# Altium schematic sheet [1]_STLU01.SchDoc([1]_STLU01)
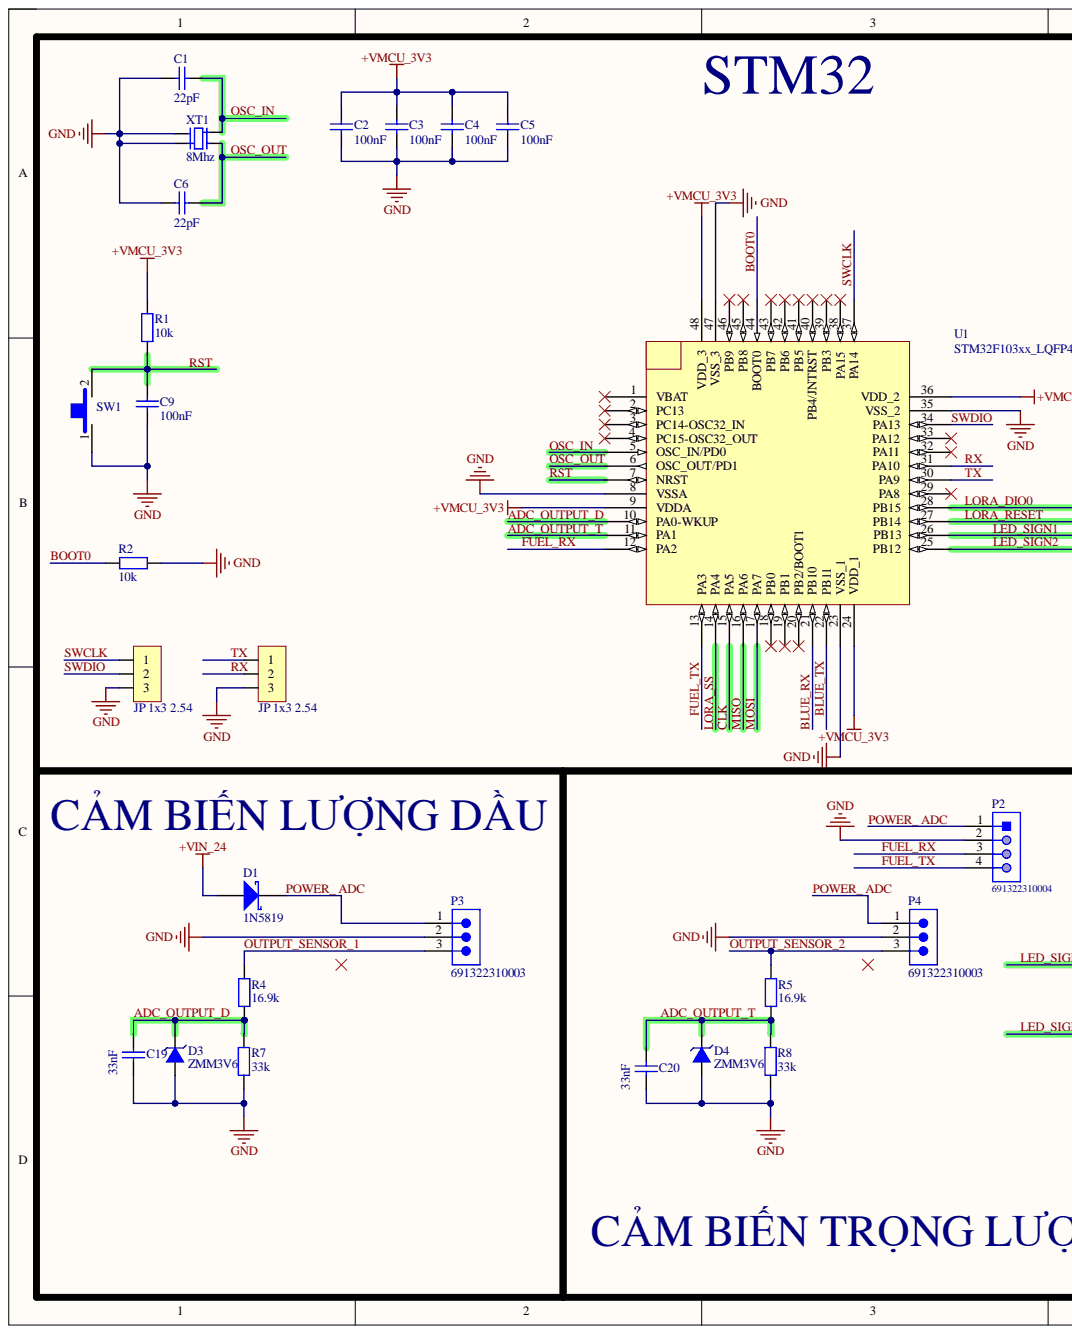
[diagram p0: [1]_STLU01.SchDoc, left]
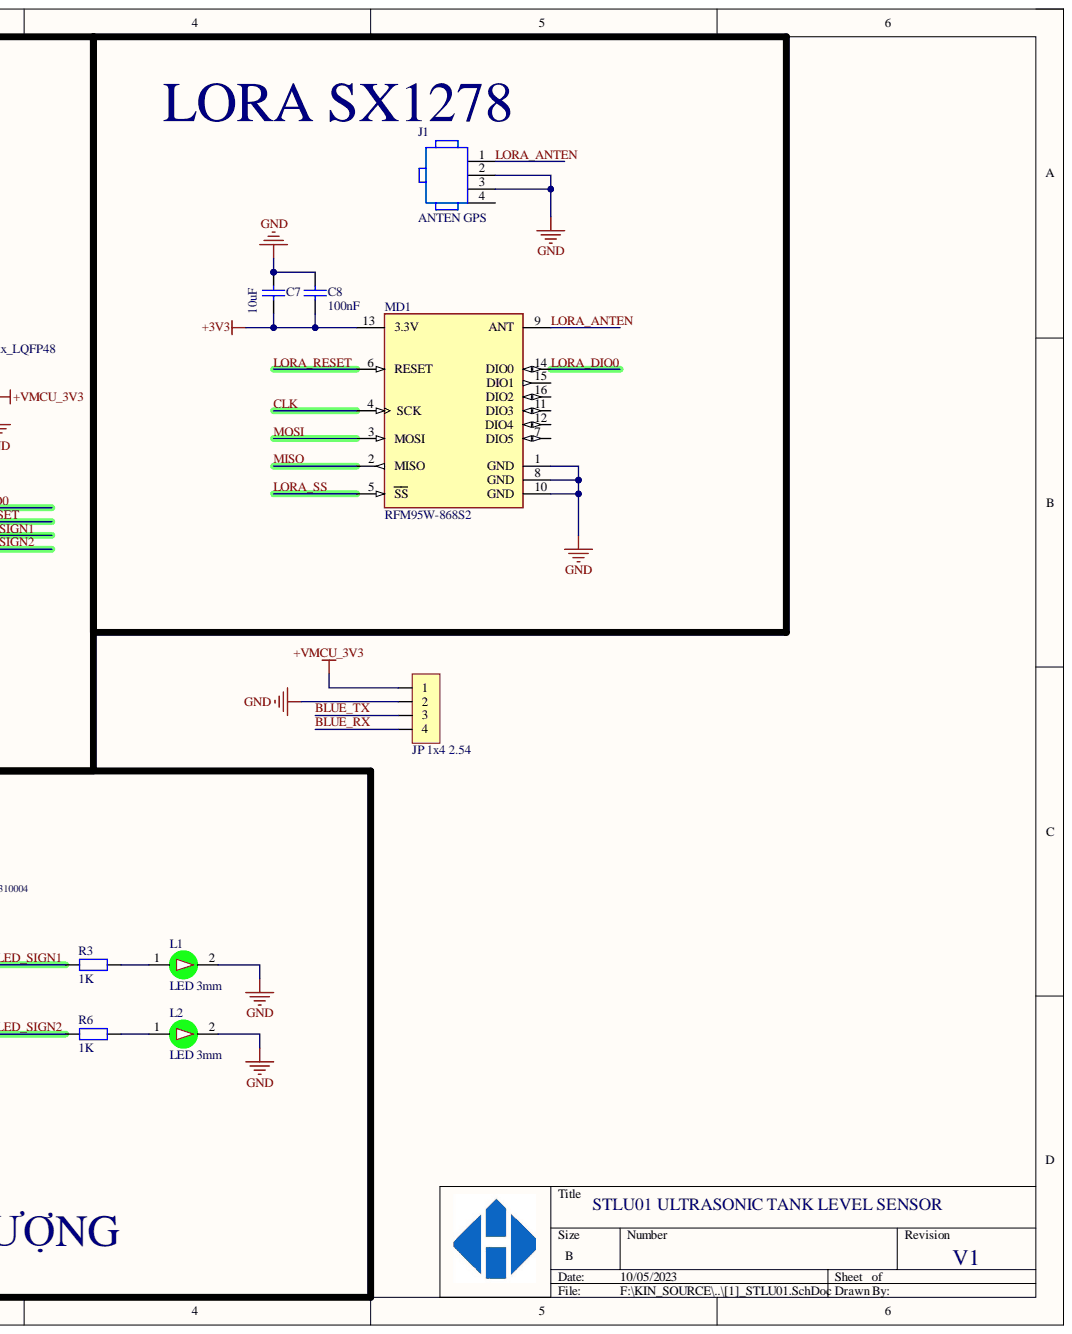
[diagram p1: [1]_STLU01.SchDoc, right]

## Parts
C1  22pF  [tu 0603]
C2  100nF  [tu 0603]
C3  100nF  [tu 0603]
C4  100nF  [tu 0603]
C5  100nF  [tu 0603]
C6  22pF  [tu 0603]
C7  10uF  [tu 0603]
C8  100nF  [tu 0603]
C9  100nF  [tu 0603]
C19  33nF  [tu 0603]
C20  33nF  [tu 0603]
D1  1N5819  [DO-214AA]
D3  ZMM3V6  [SOD_80]
D4  ZMM3V6  [SOD_80]
J1  ANTEN GPS  [TG-RECE-20369-001E-4_V]
JP1  JP 1x3 2.54  [JP 1x3 DT]
JP2  JP 1x3 2.54  [JP 1x3 DT]
JP3  JP 1x4 2.54  [JP 1x4 DT]
L1  LED 3mm  [LED-3MM-GREEN]
L2  LED 3mm  [LED-3MM-GREEN]
MD1  RFM95W-868S2  [FP-RFM95W-868S2-MFG]
P2  691322310004  [691322310004]
P3  691322310003  [691322310003]
P4  691322310003  [691322310003]
R1  10k  [tro smd 0603]
R2  10k  [tro smd 0603]
R3  1K  [tro smd 0805]
R4  16.9k  [tro smd 0805]
R5  16.9k  [tro smd 0805]
R6  1K  [tro smd 0805]
R7  33k  [tro smd 0805]
R8  33k  [tro smd 0805]
SW1  Tactile  [SW 2P SMD]  (value not drawn)
U1  STM32F103xx_LQFP48  [STM32_LQPF48]
XT1  8Mhz  [Thach Anh 4 chan 1]

## Nets
+3V3: C7.2 C8.2 MD1.13(3.3V)
+VIN_24: D1.1
+VMCU_3V3: C2.1 C3.1 C4.1 C5.1 JP3.1 R1.2 U1.9(VDDA) U1.24(VDD_1) U1.36(VDD_2) U1.48(VDD_3)
GND: C1.2 C2.2 C3.2 C4.2 C5.2 C6.2 C7.1 C8.1 C9.2 C19.2 C20.2 D3.1 D4.1 J1.2 J1.3 JP1.3 JP2.3 JP3.2 L1.2 L2.2 MD1.1(GND) MD1.8(GND) MD1.10(GND) P2.2 P3.2 P4.2 R2.1 R7.1 R8.1 SW1.1 U1.8(VSSA) U1.23(VSS_1) U1.35(VSS_2) U1.47(VSS_3) XT1.2 XT1.4
ADC_OUTPUT_D: C19.1 D3.2 R4.1 R7.2 U1.10(PA0-WKUP)
ADC_OUTPUT_T: C20.1 D4.2 R5.1 R8.2 U1.11(PA1)
BLUE_RX: JP3.4 U1.21(PB10)
BLUE_TX: JP3.3 U1.22(PB11)
BOOT0: R2.2 U1.44(BOOT0)
CLK: MD1.4(SCK) U1.15(PA5)
FUEL_RX: P2.3 U1.12(PA2)
FUEL_TX: P2.4 U1.13(PA3)
LED_SIGN1: R3.2 U1.26(PB13)
LED_SIGN2: R6.2 U1.25(PB12)
LORA_ANTEN: J1.1 MD1.9(ANT)
LORA_DIO0: MD1.14(DIO0) U1.28(PB15)
LORA_RESET: MD1.6(RESET) U1.27(PB14)
LORA_SS: MD1.5(SS) U1.14(PA4)
MISO: MD1.2(MISO) U1.16(PA6)
MOSI: MD1.3(MOSI) U1.17(PA7)
OSC_IN: C1.1 U1.5(OSC_IN/PD0) XT1.3
OSC_OUT: C6.1 U1.6(OSC_OUT/PD1) XT1.1
OUTPUT_SENSOR_1: P3.3 R4.2
OUTPUT_SENSOR_2: P4.3 R5.2
POWER_ ADC: D1.2 P2.1 P3.1 P4.1
RST: C9.1 R1.1 SW1.2 U1.7(NRST)
RX: JP2.2 U1.31(PA10)
SWCLK: JP1.1 U1.37(PA14)
SWDIO: JP1.2 U1.34(PA13)
TX: JP2.1 U1.30(PA9)
NetL1_1: L1.1 R3.1
NetL2_1: L2.1 R6.1

Not connected: J1 4; MD1 7(DIO5) 11(DIO3) 12(DIO4) 15(DIO1) 16(DIO2); U1 1(VBAT) 2(PC13) 3(PC14-OSC32_IN) 4(PC15-OSC32_OUT) 18(PB0) 19(PB1) 20(PB2/BOOT1) 29(PA8) 32(PA11) 33(PA12) 38(PA15) 39(PB3) 40(PB4/JNTRST) 41(PB5) 42(PB6) 43(PB7) 45(PB8) 46(PB9)

## Library data of the parts
C1 C6 = Tu 0603 101 50V 10%: Dán; Don_Vi: Con; Ma_Hang: MH004565; So_Chan: 2; Ten_Linh_Kien: Tụ 0603 101-0.1nF 10% 50V; Chung_Loai: Dán; Component Kind: Standard; Library Name: Altium_Lib_by_KhueNguyenCreator.IntLib
C2-C5 C8 = Tu 0603 104 50V 10%: Dán; Don_Vi: Con; Ma_Hang: MH004568; So_Chan: 2; Ten_Linh_Kien: Tụ 0603 104-100nF 10% 50V; Chung_Loai: Dán; Component Kind: Standard; Library Name: Altium_Lib_by_KhueNguyenCreator.IntLib
C7 C19 C20 = Tu 0603 105 16V 20%: Dán; Don_Vi: Con; Ma_Hang: MH004574; So_Chan: 2; Ten_Linh_Kien: Tụ 0603 105-1uF 20% 16V; Chung_Loai: Dán; Component Kind: Standard; Library Name: Altium_Lib_by_KhueNguyenCreator.IntLib
C9 = Tu 0603 105 50V 10%: Dán; Don_Vi: Con; Ma_Hang: MH004569; So_Chan: 2; Ten_Linh_Kien: Tụ 0603 105-1uF 50V 10%; Chung_Loai: Dán; Component Kind: Standard; Library Name: Altium_Lib_by_KhueNguyenCreator.IntLib
D1 = DIO_SS14: DIODE SCHOTTKY 1A 40V SMA DO-214AA; Manufacturer: Fairchild; Part number: SS14; Component Kind: Standard; ComponentLink1Description: Link product; ComponentLink1URL: https://www.thegioiic.com/ss14-sma-diode-schottky-1a-1n5819; Cost: 600; Package: DO-214AA; Library Name: COMPONENT_INS.IntLib
D3 D4 = DIO_ZMM3V6: ZMM3V6 3.6V 500mW SMD SOD-80; Manufacturer: On Semiconductor; Component Kind: Standard; ComponentLink1Description: Link product; ComponentLink1URL: https://www.thegioiic.com/zmm3v6-diode-zener-3-6v-500mw-smd; Cost: 250; Package: SOD-80; Part number: ZMM3V6; Library Name: COMPONENT_INS.IntLib
J1 = CMP-0275-00001-2: RF Connector, Onboard Receptacle, IPEX MHFIII; Manufacturer: Taoglas; PartNumber: RECE-20369-001E-01; Packing: Tape and Reel; Component Kind: Standard; ComponentLink1Description: Manufacturer URL; ComponentLink1URL: http://www.taoglas.com/; ComponentLink2Description: Datasheet; ComponentLink2URL: http://www.taoglas.com/images/product_images/original_images/RECE.20369.001E.01.pdf; ComponentLink3Description: Package Specification; ComponentLink3URL: http://www.taoglas.com/images/product_images/original_images/RECE.20369.001E.01.pdf; DatasheetVersion: Rev. C; Housing Color: Gold; Library Name: Altium Content Vault; Mounting Technology: Surface Mount; PackageDescription: 4-Pin, Hand Drawn, Body 1.7x1.7mm; PackageReference: RECE-20369-001E-01-4; PackageVersion: Rev. C
JP1 JP2 = JP 1x3 2.54 Duc Thang: Cắm; Don_Vi: Con; Ma_Hang: MH000903; Ten_Linh_Kien: Jump Đơn Đực 2.54MM 1x40P Thẳng 11MM; Chung_Loai: Cắm; Component Kind: Standard; Library Name: Altium_Lib_by_KhueNguyenCreator.IntLib
JP3 = JP 1x4 2.54 Duc Thang: Cắm; Don_Vi: Con; Ma_Hang: MH000903; Ten_Linh_Kien: Jump Đơn Đực 2.54MM 1x40P Thẳng 11MM; Chung_Loai: Cắm; Component Kind: Standard; Library Name: Altium_Lib_by_KhueNguyenCreator.IntLib
L1 L2 = LED 3mm Xanh La: Cắm; Don_Vi: Con; Ma_Hang: MH001054; Ten_Linh_Kien: Led 3MM Phủ Xanh (10c); Chung_Loai: Cắm; Component Kind: Standard; Library Name: Altium_Lib_by_KhueNguyenCreator.IntLib
MD1 = CMP-204316-000001-1: RX TXRX MODULE ISM < 1GHZ SMD; Current - Receiving: 12.1mA; Current - Transmitting: 120mA; Data Rate: 300Kbps; Frequency: 868MHz; Interface: SPI; Sensitivity: -123dBm; Case/Package: SMD/SMT; Component Kind: Standard; Library Name: Altium Content Vault; Max Operating Temperature: 70°C; Min Operating Temperature: -20°C; Mount: Surface Mount; Packaging: Bulk
P2 = CMP-1502-01888-1: Serie 322 - 3.81mm Horizontal PCB Header WR-TBL, 4 pin; Manufacturer: Wurth Elektronik; Gender: Male; Pin Number: 4; Component Kind: Standard; Datasheet URL: http://katalog.we-online.de/em/datasheet/6913223100xx.pdf; Library Name: Altium Content Vault; Manufacturer URL: http://www.we-online.de; Mounting Technology: THT; Operating Temperature: -40to105degC; Package Reference: 691322310004; Packaging: Box; Part Number: 691322310004; Pitch: 3.81mm; Publisher: WurthElektronik
P3 P4 = CMP-1502-01887-2: NexarPartId: 8917778; Current: 10A; Gender: Male; Number of Contacts: 3; Number of Levels: 1; Pitch: 3.81mm; Voltage: 300V; Color: Green; Component Kind: Standard; Contact Material: Brass; Contact Plating: Tin; Height: 9.2mm; Lead Pitch: 3.81mm; Length: 10.5mm; Library Name: Altium Content Vault; Mount: Through Hole; Number of Pins: 3; Number of Positions Per Level: 3; Number of Rows: 1; Packaging: Box; REACH SVHC: Unknown; RoHS: Compliant; Termination: Solder; Width: 12.82mm
R1 R2 = Tro 0603 5% 10k: Dán; Don_Vi: Con; Ma_Hang: MH006316; So_Chan: 2; Ten_Linh_Kien: Trở 0603 5% 10k; Chung_Loai: Dán; Component Kind: Standard; Library Name: Altium_Lib_by_KhueNguyenCreator.IntLib
R3-R8 = Tro 0805 5% 1k: Dán; Don_Vi: Con; Ma_Hang: MH005234; So_Chan: 2; Ten_Linh_Kien: Trở 0805 5% 1k; Chung_Loai: Dán; Component Kind: Standard; Library Name: Altium_Lib_by_KhueNguyenCreator.IntLib
SW1 = SW_SPDT_2PIN: SMD Mini Push Button Switch 2 Pin 3x6x2.7mm; Manufacturer: OEM; Part number: SPST 2 PIN SMD; Component Kind: Standard; ComponentLink1Description: Link product; ComponentLink1URL: https://www.thegioiic.com/nut-nhan-3-5x6mm-cao-2-5mm-2-chan-smd-dau-trang; Cost: 600; Library Name: COMPONENT_INS.IntLib
XT1 = Thach Anh 4 Chan SMD: Crystal For all Crystal 2pin.; Value: 4Mhz; Component Kind: Standard; Library Name: Altium_Lib_by_KhueNguyenCreator.IntLib
U1 = STM32F103xx_LQFP48: Component Kind: Standard; ComponentLink1Description: Manufacturer Link; ComponentLink1URL: www.st.com/stm32; ComponentLink2Description: Datasheet; ComponentLink2URL: http://www.st.com/stonline/products/literature/ds/13587.pdf; DatasheetVersion: rev6, Mar-2008; PackageDescription: 48-Pin Low-Profile Quad Flat Pack, 7 x 7 mm Body, 0.5 mm Pitch (LQFP48); PackageReference: LQFP48; PackageVersion: Mar-2008; Published: 30-May-2008; Library Name: Altium_Lib_by_KhueNguyenCreator.IntLib; Publisher: Altium Limited
